# Altium schematic sheet AFE.SchDoc(AFE)
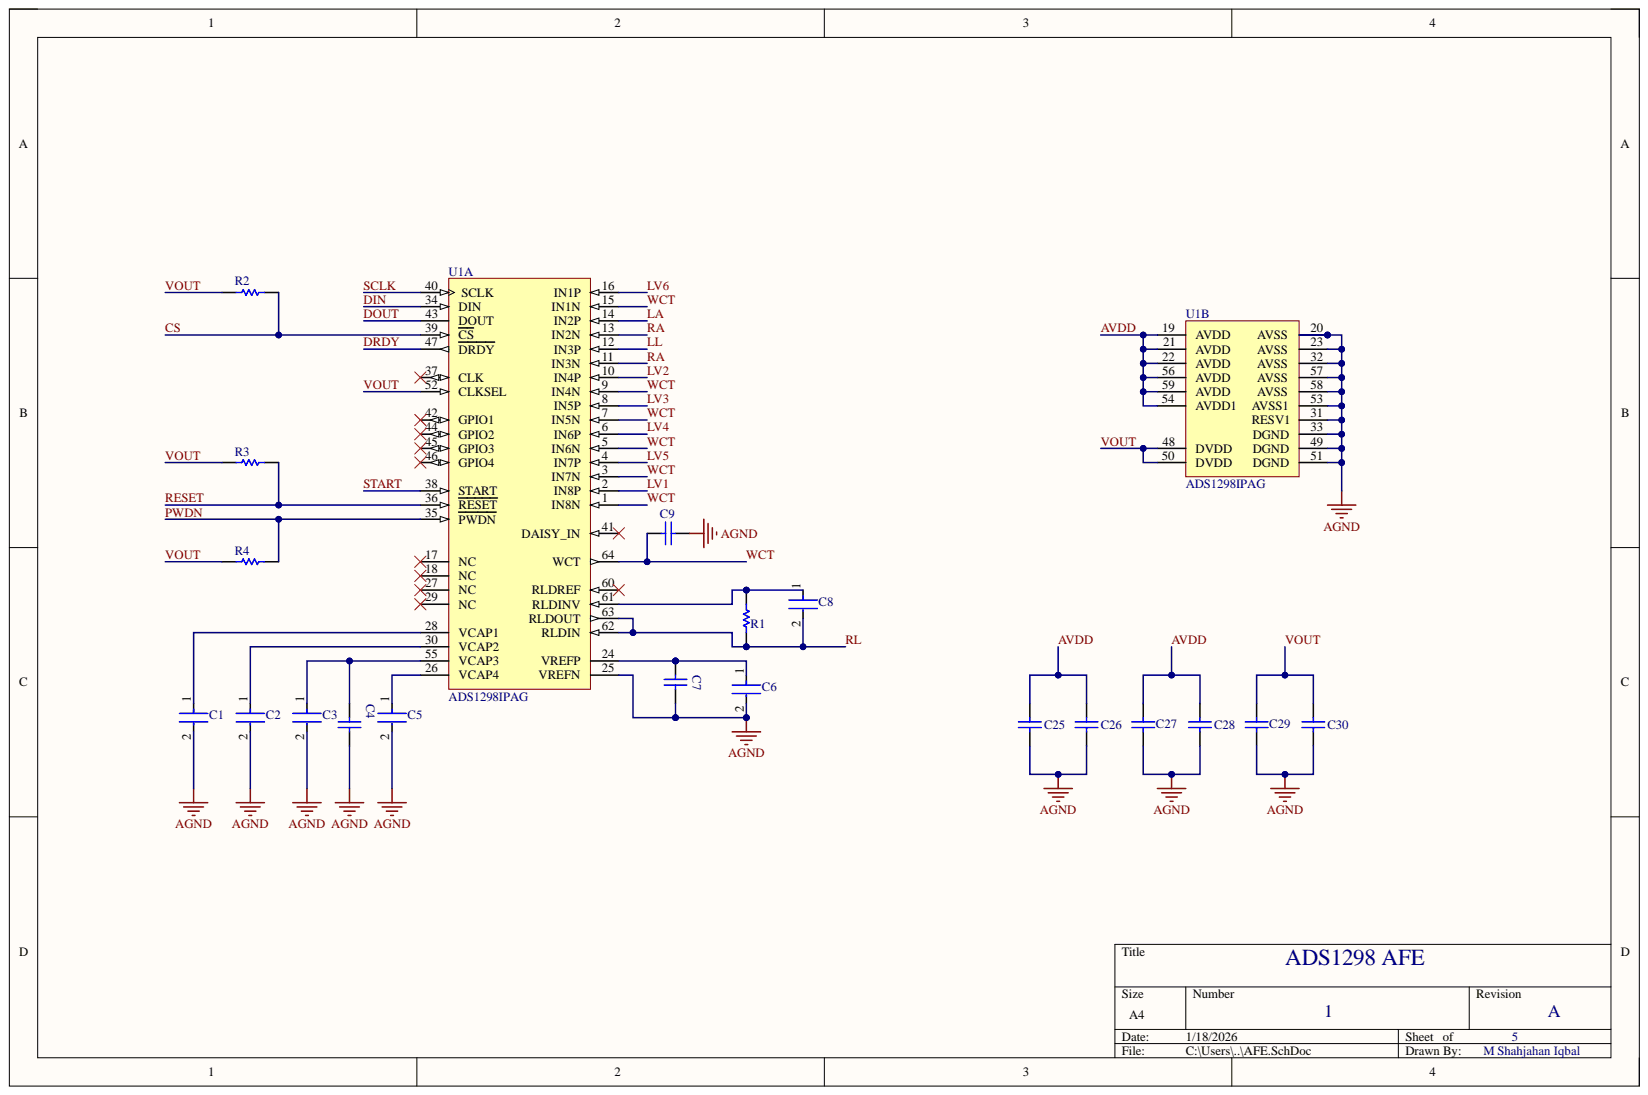
[diagram p0: AFE.SchDoc]

## Parts
C1  CL21A226KQQNNNF  [FP-CL21-IPC_C]  (value not drawn)
C2  TMK107B7105KA-T  [FP-0603-L_1_6_0_1-W_0_8_0-IPC_A]  (value not drawn)
C3  TMK107B7105KA-T  [FP-0603-L_1_6_0_1-W_0_8_0-IPC_A]  (value not drawn)
C4  885012206095  [WCAP-CSGP_0603_L1.6W0.8H0.8_Reflow]  (value not drawn)
C5  TMK107B7105KA-T  [FP-0603-L_1_6_0_1-W_0_8_0-IPC_A]  (value not drawn)
C6  CL21X106KPCLRNC  [FP-CL21-IPC_A]  (value not drawn)
C7  885012206095  [WCAP-CSGP_0603_L1.6W0.8H0.8_Reflow]  (value not drawn)
C8  CGA3E2X7R1H103K080AE  [FP-CGA3E-0_3-0_1-IPC_B]  (value not drawn)
C9  CC0603JRNPO9BN101  [CAPC1608X90X40ML10T20]  (value not drawn)
C25  GRM188R61A105KA61D  [CAPC1608X90X35LL10T15]  (value not drawn)
C26  885012206020  [WCAP-CSGP_0603_L1.6W0.8H0.8_Reflow]  (value not drawn)
C27  GRM188R61A105KA61D  [CAPC1608X90X35LL10T15]  (value not drawn)
C28  885012206020  [WCAP-CSGP_0603_L1.6W0.8H0.8_Reflow]  (value not drawn)
C29  GRM188R61A105KA61D  [CAPC1608X90X35LL10T15]  (value not drawn)
C30  885012206020  [WCAP-CSGP_0603_L1.6W0.8H0.8_Reflow]  (value not drawn)
R1  RK73H1JTTD3923F  [RESC1608X55X30LL20T10]  (value not drawn)
R2  RC0603FR-1010KL  [RESC1608X55X25LL10T15]  (value not drawn)
R3  RC0603FR-1010KL  [RESC1608X55X25LL10T15]  (value not drawn)
R4  RC0603FR-1010KL  [RESC1608X55X25LL10T15]  (value not drawn)
U1  ADS1298IPAG  [TI-PAG64_L]  (parts A,B)

## Nets
AGND: C1.2 C2.2 C3.2 C4.2 C5.2 C6.2 C7.2 C9.2 C25.2 C26.2 C27.2 C28.2 C29.2 C30.2 U1.20(AVSS) U1.23(AVSS) U1.25(VREFN) U1.31(RESV1) U1.32(AVSS) U1.33(DGND) U1.49(DGND) U1.51(DGND) U1.53(AVSS1) U1.57(AVSS) U1.58(AVSS)
AVDD: C25.1 C26.1 C27.1 C28.1 U1.19(AVDD) U1.21(AVDD) U1.22(AVDD) U1.54(AVDD1) U1.56(AVDD) U1.59(AVDD)
CS: R2.2 U1.39(CS)
DIN: U1.34(DIN)
DOUT: U1.43(DOUT)
DRDY: U1.47(DRDY)
LA: U1.14(IN2P)
LL: U1.12(IN3P)
LV1: U1.2(IN8P)
LV2: U1.10(IN4P)
LV3: U1.8(IN5P)
LV4: U1.6(IN6P)
LV5: U1.4(IN7P)
LV6: U1.16(IN1P)
PWDN: R4.2 U1.35(PWDN)
RA: U1.11(IN3N) U1.13(IN2N)
RESET: R3.2 U1.36(RESET)
RL: C8.2 R1.2 U1.62(RLDIN) U1.63(RLDOUT)
SCLK: U1.40(SCLK)
START: U1.38(START)
VOUT: C29.1 C30.1 R2.1 R3.1 R4.1 U1.48(DVDD) U1.50(DVDD) U1.52(CLKSEL)
WCT: C9.1 U1.1(IN8N) U1.3(IN7N) U1.5(IN6N) U1.7(IN5N) U1.9(IN4N) U1.15(IN1N) U1.64(WCT)
NetC1_1: C1.1 U1.28(VCAP1)
NetC2_1: C2.1 U1.30(VCAP2)
NetC3_1: C3.1 C4.1 U1.55(VCAP3)
NetC5_1: C5.1 U1.26(VCAP4)
NetC6_1: C6.1 C7.1 U1.24(VREFP)
NetC8_1: C8.1 R1.1 U1.61(RLDINV)

Not connected: U1 17(NC) 18(NC) 27(NC) 29(NC) 37(CLK) 41(DAISY_IN) 42(GPIO1) 44(GPIO2) 45(GPIO3) 46(GPIO4) 60(RLDREF)

## Library data of the parts
C1 = CMP-13271-001543-1: CL21 Series 0805 22uF 6.3V ±10% Tolerance X5R Multilayer Ceramic Chip Capacitor; Capacitance: 22uF; Case/Package: 0805; Component Kind: Standard; Depth: 1.25mm; Dielectric: X5R; Height: 1.25mm; Length: 2mm; Library Name: Altium Content Vault; Max Operating Temperature: 85°C; Min Operating Temperature: -55°C; Mount: Surface Mount; Package Quantity: 10000; Packaging: Tape and Reel; Radiation Hardening: No; Thickness: 0.055inch; Tolerance: 10%; Voltage Rating: 6.3V; Voltage Rating (DC): 6.3V; Width: 0.049inch
C2 C3 C5 = CMP-2000-07259-3: None; Capacitance: 1uF; Dielectric: X7R; Tolerance: 10%; Voltage Rating: 25V; Case Code (Imperial): 0603; Case Code (Metric): 1608; Case/Package: 0603; Component Kind: Standard; Construction: Flat; Contact Plating: Tin; Depth: 0.8mm; Halogen Free: Halogen Free; Height: 0.8mm; Length: 1.6mm; Library Name: Altium Content Vault; Max Operating Temperature: 125°C; Min Operating Temperature: -55°C; Mount: Surface Mount; Number of Pins: 2; Package Quantity: 1; Packaging: Tape and Reel; Radiation Hardening: No; REACH SVHC: No SVHC; Resistor Type: Ceramic; RoHS Compliant: Yes; Series: M; Termination: SMD/SMT; Thickness: 0.035inch; Voltage Rating (DC): 25V; Width: 0.031inch
C4 C7 = CMP-207839-000004-3: Ceramic Capacitor, Multilayer, Ceramic, 50V, 10% +Tol, 10% -Tol, X7R, 15% TC, 0.1uF, Surface Mount, 0603; Capacitance: 100nF; Insulation Resistance: 5GΩ; Case Code (Imperial): 0603; Case Code (Metric): 1608; Case/Package: 0603; Component Kind: Standard; Dielectric: X7R; Height: 800µm; Length: 1.6mm; Library Name: Altium Content Vault; Material: Ceramic; Max Operating Temperature: 125°C; Min Operating Temperature: -55°C; Mount: Surface Mount; REACH SVHC: Unknown; RoHS: Compliant; Thickness: 939.8µm; Tolerance: 10%; Voltage Rating: 50V; Voltage Rating (DC): 50V; Width: 800µm
C6 = CMP-13271-002310-1: CL21 Series 0805 10uF 10V ±10% Tolerance X6S Multilayer Ceramic Chip Capacitor; Capacitance: 10uF; Case Code (Imperial): 0805; Case Code (Metric): 2012; Case/Package: 0805; Component Kind: Standard; Depth: 1.25mm; Dielectric: X6S; Height: 0.85mm; Length: 2mm; Library Name: Altium Content Vault; Max Operating Temperature: 105°C; Min Operating Temperature: -55°C; Mount: Surface Mount; Package Quantity: 1; Packaging: Tape and Reel; Radiation Hardening: No; Thickness: 0.037inch; Tolerance: 10%; Voltage Rating: 10V; Width: 0.049inch
C8 = CMP-08246-000510-1: Cap Ceramic 10nF 50V X7R 10% Pad SMD 0603 +125°C Automotive T/R; Capacitance: 10nF; Case Code (Imperial): 0603; Case Code (Metric): 1608; Case/Package: 0603; Component Kind: Standard; Dielectric: X7R; Features: Soft Termination; Height: 0.8mm; Length: 0.063inch; Library Name: Altium Content Vault; Max Operating Temperature: 125°C; Min Operating Temperature: -55°C; Mount: Surface Mount; Package Quantity: 4000; Packaging: Tape and Reel; Ratings: AEC-Q200; Termination: SMD/SMT; Thickness: 0.035inch; Tolerance: 10%; Voltage Rating: 50V; Voltage Rating (DC): 50V; Weight: 7.1E-05oz; Width: 0.031inch
C9 = CMP-2100-03594-1: Chip Capacitor, 100 pF, +/- 5%, 50 V, -55 to 125 degC, 0603 (1608 Metric), RoHS, Tape and Reel; Manufacturer: Yageo; Capacitance: 100pF; Dielectric: C0G; Insulation Resistance: 100GR; Temperature Coefficient: 30ppm/degC; Voltage: 50V; Case Code (Imperial): 0603; Case Code (Metric): 1608; Case/Package: 0603; Component Kind: Standard; Composition: Ceramic; Datasheet URL: http://www.yageo.com/documents/recent/UPY-GP_NP0_16V-to-50V_14.pdf; Datasheet Version: Rev. 14, 07/2016; Depth: 0.8mm; Height: 0.8mm; Lead Free: LeadFree; Length: 1.6mm; Library Name: Altium Content Vault; Manufacturer URL: http://www.yageo.com/; Max Operating Temperature: 125degC; Min Operating Temperature: -55degC; Mount: SurfaceMount; Number of Pins: 2; Package Description: 2-Pin Surface Mount Device, Body 1.6 x 0.8 mm; Package Quantity: 1; Package Reference: 0603; Packaging: TapeandReel; Radiation Hardening: No; REACH SVHC: Unknown; RoHSCompliant: True; Termination: SMD/SMT; Thickness: 0.035inch; Tolerance: 5%; Voltage Rating: 50V; Voltage Rating (DC): 50V; Width: 0.8mm
C25 C27 C29 = CMP-2000-06336-1: Chip Capacitor, 1 uF, +/- 10%, 10 V, -55 to 85 degC, 0603 (1608 Metric), RoHS, Tape and Reel; Manufacturer: Murata; Brand: MurataElectronics; Capacitance: 1uF; Capacitance - nF: 1000nF; Case Height: 0.8mm; Case Length: 1.6mm; Dielectric: X5R; Temperature Coefficient / Code: +/-15%; Type: GeneralPurposeMLCC; Voltage Rating: 10V; Case Code - in: 0603; Case Code - mm: 1608; Case Width: 0.8mm; Component Kind: Standard; Datasheet URL: http://search.murata.co.jp/Ceramy/image/img/A01X/G101/ENG/GRM188R61A105KA61-01.pdf; Datasheet Version: 02/2016; Dimensions: 0.8mmWx1.6mmLx0.8mmH; Factory Pack Quantity: 4000; Library Name: Altium Content Vault; Manufacturer URL: http://www.murata.com/; Maximum Operating Temperature: +85C; Minimum Operating Temperature: -55C; Mounting Technology: SurfaceMount; Operating Temperature Range: -55Cto+85C; Package / Case: 0603(1608metric); Package Description: 2-Pin Surface Mount Device, Body 1.6 x 0.8 mm; Package Reference: 0603; Packaging: Reel; Product: GeneralTypeMLCCs; Product Category: MultilayerCeramicCapacitorsMLCC-SMD/SMT; RoHS: Details; Series: GRM; Termination Style: SMD/SMT; Tolerance: 10%; Voltage Rating DC: 10V
C26 C28 C30 = CMP-03913-53914729-2: Capacitor 100NF Multilayer Ceramic MLCC10 V , X7R Dielectric 0603 Surface Mount; Insulation Resistance: 5GΩ; Tolerance: 10%; Voltage Rating: 10V; Capacitance: 100nF; Case Code (Imperial): 0603; Case Code (Metric): 1608; Case/Package: 0603; Component Kind: Standard; Dielectric: X7R; Height: 800µm; Length: 1.6mm; Library Name: Altium Content Vault; Max Operating Temperature: 125°C; Min Operating Temperature: -55°C; Mount: Surface Mount; REACH SVHC: Unknown; RoHS: Compliant; Thickness: 863.6µm; Voltage Rating (DC): 10V; Width: 800µm
R1 = CMP-2000-01477-1: Manufacturer: KOA Speer; Component Kind: Standard; Library Name: Altium Content Vault; Part Number: RK73H1JTTD3923F
R2-R4 = CMP-2000-06948-1: Chip Resistor, 10 kOhm, +/- 1%, 0.1 W, -55 to 155 degC, 0603 (1608 Metric), RoHS, Tape and Reel; Manufacturer: Yageo; Case Style: Molded; Power Rating(s): 1/10W; Product Depth (mm): 0.8mm; Product Height (mm): 0.45mm; Product Length (mm): 1.6mm; Resistance: 10kohm; Temperature Coefficient: ±100; Component Kind: Standard; Construction: Rectangular; Datasheet URL: http://www.yageo.com/documents/recent/PYu-RC_Group_51_RoHS_L_7.pdf; Datasheet Version: Rev. 7, 05/2017; Failure Rate: NotRequired; Library Name: Altium Content Vault; Manufacturer URL: http://www.yageo.com/; Military Standard: NotRequired; Mounting Technology: SurfaceMount; Operating Temp Range: -55Cto155C; Package / Case: 0603; Package Description: 2-Pin Surface Mount Device, Body 1.6 x 0.8 mm; Package Reference: 0603; Packaging: TapeandReel; Termination Style: NotRequired; Tolerance (+ or -): 1%; Type: ThickFilm
U1 = CMP-0003-00595-1: 8-Channel, 24-Bit ADC with Integrated ECG Front End, -40 to 85 degC, 64-pin QFP (PAG64), Green (RoHS & no Sb/Br); Manufacturer: Texas Instruments; # Input Channels: 8; Analog Voltage AV/DD(Max)(V): 5.25; Analog Voltage AV/DD(Min)(V): 2.7; Architecture: Delta-Sigma; Digital Supply(Max)(V): 3.6; Digital Supply(Min)(V): 1.65; Interface: Serial SPI; Resolution(Bits): 24; Sample Rate (max)(SPS): 32K; SNR(dB): 112; SubFamily: Precision ADC (<=10MSPS); Code_JEDEC: MS-026; Component Kind: Standard; ComponentLink1Description: Manufacturer URL; ComponentLink1URL: http://www.ti.com/; ComponentLink2Description: Datasheet; ComponentLink2URL: http://www.ti.com/general/docs/lit/getliterature.tsp?genericPartNumber=ADS1298&fileType=pdf; ComponentLink3Description: Package Specification; ComponentLink3URL: http://www.ti.com/lit/ml/mtqf006a/mtqf006a.pdf; DatasheetVersion: SBAS459I; Eco-Plan: Green (RoHS & no Sb/Br); Library Name: Altium Content Vault; Mounting Technology: Surface Mount; PackageDescription: 64-Pin Plastic Flat Pack, Body 10 x 10 mm, Pitch 0.50 mm; PackageReference: PAG64; PackageVersion: 4040282/C, 11/1996; PartNumber: ADS1298IPAG
